# Altium schematic sheet Front end power supplies.SchDoc (continued)

## Library data of the parts
C194 C451 C452 = INVIHA-49: CAP ALUM 33UF 20% 200V SMD 10mm dia; ref_part_type: /capacitor/polarized/al/; source_manufacturer_1: Nichicon; source_manufacturer_1_pn: ULR2D330MNL1GS; cae_altium_pcb_status: Prototype; Database Table Name: altium_default; db_rev: 7664; elec_temp_range: -40 to 105 ° C; phy_height: 14.5; phy_material: Al; phy_packaging_type: Radial, CAN; ref_datasheet: INVIHA-10; ref_keyword: Aluminum electrolytic capacitor; cae_altium_sch_status: Prototype; Component Kind: Standard; ComponentLink1Description: Datasheet; ComponentLink1URL: https://cop201.prevas.dk/viewvc/datasheet/INVIHA-10.pdf?root=hw_components&view=co; db_created: 2015-08-05 05:06:02.0; db_last_updated: 05-08-2015 05:06:03; db_local_id: INVIHA-49; db_status: Prototype; elec_tolerance: 20%; elec_value: 33µF; elec_voltage_rating: 200V; phy_mounting: SMD; Library Name: altium_mysql.dblib
C208 C215 C242 C445 C450 C479 C484 C551 = INNISI-82: CAP CER 10nF 50V 10% X7R 0402; ref_part_type: /capacitor/non_polarized/ceramic/; source_manufacturer_1: Yageo; source_manufacturer_1_pn: CC0402KRX7R9BB103; cae_altium_pcb_status: Prototype; Database Table Name: altium_default; db_rev: 7579; elec_temp_range: -55 to +125C; phy_height: 0.55mm; phy_mounting: SMD; phy_packaging_type: 0402; ref_datasheet: INNISI-72; ref_keyword: Ceramic capacitor; cae_altium_sch_status: Prototype; Component Kind: Standard; ComponentLink1Description: Datasheet; ComponentLink1URL: https://cop201.prevas.dk/viewvc/datasheet/INNISI-72.pdf?root=hw_components&view=co; db_created: 2015-08-03 05:52:48.0; db_last_updated: 03-08-2015 05:52:50; db_local_id: INNISI-82; db_status: Prototype; elec_tolerance: 10%; elec_value: 10.0nF; elec_voltage_rating: 50V; phy_material: X7R; Library Name: altium_mysql.dblib
C240 C243 C546 = INVIHA-50: CAP ALUM 680UF 20% 10V SMD 8mm dia; ref_part_type: /capacitor/polarized/al/; source_manufacturer_1: Nichicon; source_manufacturer_1_pn: UCL1A681MNL1GS; cae_altium_pcb_status: Prototype; Database Table Name: altium_default; db_rev: 7665; elec_temp_range: -55°C ~ 105°C; phy_height: 10mm; phy_material: Al; phy_packaging_type: Radial, CAN; ref_datasheet: INVIHA-11; ref_keyword: Aluminum electrolytic capacitor; cae_altium_sch_status: Prototype; Component Kind: Standard; ComponentLink1Description: Datasheet; ComponentLink1URL: https://cop201.prevas.dk/viewvc/datasheet/INVIHA-11.pdf?root=hw_components&view=co; db_created: 2015-08-05 05:11:26.0; db_last_updated: 05-08-2015 05:11:28; db_local_id: INVIHA-50; db_status: Prototype; elec_tolerance: 20%; elec_value: 680uF; elec_voltage_rating: 10V; phy_mounting: SMD; Library Name: altium_mysql.dblib
C241 C550 C552 = INNISI-73: CAP CER 0.1UF 50V 10% X5R 0402; ref_part_type: /capacitor/non_polarized/ceramic/; source_manufacturer_1: TDK Corporation; source_manufacturer_1_pn: C1005X5R1H104K050BB; cae_altium_pcb_status: Prototype; Database Table Name: altium_default; db_rev: 7569; elec_temp_range: -55 to +85 C; phy_height: 0.55mm; phy_mounting: SMD; phy_packaging_type: 0402; ref_datasheet: INNISI-71; cae_altium_sch_status: Prototype; Component Kind: Standard; ComponentLink1Description: Datasheet; ComponentLink1URL: https://cop201.prevas.dk/viewvc/datasheet/INNISI-71.pdf?root=hw_components&view=co; db_created: 2015-08-03 03:34:59.0; db_last_updated: 03-08-2015 03:35:01; db_local_id: INNISI-73; db_status: Prototype; elec_tolerance: ±10%; elec_value: 0.1uF; elec_voltage_rating: 50V; phy_material: X5R; ref_keyword: CAP CER 0.1UF 50V 10% X5R 0402; Library Name: altium_mysql.dblib
C264 C548 = INNISI-85: CAP CER 1.8nF 50V 10% X7R 0402; ref_part_type: /capacitor/non_polarized/ceramic/; source_manufacturer_1: Murata; source_manufacturer_1_pn: GRM155R71H182KA01D; cae_altium_pcb_status: Prototype; Database Table Name: altium_default; db_rev: 7588; elec_temp_range: -55 to +125C; phy_height: 0.55mm; phy_mounting: SMD; phy_packaging_type: 0402; ref_datasheet: INNISI-77; ref_keyword: Ceramic capacitor X7R 0402; cae_altium_sch_status: Prototype; Component Kind: Standard; ComponentLink1Description: Datasheet; ComponentLink1URL: https://cop201.prevas.dk/viewvc/datasheet/INNISI-77.pdf?root=hw_components&view=co; db_created: 2015-08-03 06:34:28.0; db_last_updated: 03-08-2015 06:34:30; db_local_id: INNISI-85; db_status: Prototype; elec_tolerance: 10%; elec_value: 1.8nF; elec_voltage_rating: 50V; phy_material: X7R; Library Name: altium_mysql.dblib
C265 = INNISI-80: CAP CER 33PF 50V 5% NPO 0402; ref_part_type: /capacitor/non_polarized/ceramic/; source_manufacturer_1: Yageo; source_manufacturer_1_pn: CC0402JRNPO9BN330; cae_altium_pcb_status: Prototype; Database Table Name: altium_default; db_rev: 7577; elec_temp_range: -55 to +125C; phy_height: 0.55mm; phy_material: C0G,NPO; phy_mounting: SMD; phy_packaging_type: 0402; ref_datasheet: INNISI-72; ref_keyword: Multilayer chip capacitor; cae_altium_sch_status: Prototype; Component Kind: Standard; ComponentLink1Description: Datasheet; ComponentLink1URL: https://cop201.prevas.dk/viewvc/datasheet/INNISI-72.pdf?root=hw_components&view=co; db_created: 2015-08-03 05:45:15.0; db_last_updated: 03-08-2015 05:45:17; db_local_id: INNISI-80; db_status: Prototype; elec_tolerance: 5%; elec_value: 33pF; elec_voltage_rating: 50V; Library Name: altium_mysql.dblib
C438 C441 = INNISI-86: CAP CER 22nF 50V 10% X7R 0402; ref_part_type: /capacitor/non_polarized/ceramic/; source_manufacturer_1: Murata; source_manufacturer_1_pn: GRM155R71H223KA12D; cae_altium_pcb_status: Prototype; Database Table Name: altium_default; db_rev: 7591; elec_temp_range: -55 to +125C; phy_height: 0.55mm; phy_mounting: SMD; phy_packaging_type: 0402; ref_datasheet: INNISI-78; ref_keyword: Ceramic capacitor X7R 0402; cae_altium_sch_status: Prototype; Component Kind: Standard; ComponentLink1Description: Datasheet; ComponentLink1URL: https://cop201.prevas.dk/viewvc/datasheet/INNISI-78.pdf?root=hw_components&view=co; db_created: 2015-08-03 06:44:03.0; db_last_updated: 03-08-2015 06:44:05; db_local_id: INNISI-86; db_status: Prototype; elec_tolerance: 10%; elec_value: 22nF; elec_voltage_rating: 50V; phy_material: X7R; Library Name: altium_mysql.dblib
C439 C440 = INRAAN-25: Capacitor; ref_part_type: /capacitor/non_polarized/ceramic/; source_manufacturer_1: TDK; source_manufacturer_1_pn: C3225X7S2A475M200; cae_altium_pcb_status: Prototype; Database Table Name: altium_default; db_rev: 6695; elec_temp_range: -55 to 125; elec_voltage_rating: 100V; phy_height: 2.2; phy_mounting: SMD; phy_packaging_type: 1210; ref_datasheet: INRAAN-27; cae_altium_sch_status: Prototype; Component Kind: Standard; ComponentLink1Description: Datasheet; ComponentLink1URL: https://cop201.prevas.dk/viewvc/datasheet/INRAAN-27.pdf?root=hw_components&view=co; db_created: 2013-11-18 11:20:32.0; db_last_updated: 01-09-2014 04:22:26; db_local_id: INRAAN-25; db_status: Prototype; elec_value: 4.7uF; ref_keyword: Capacitor; Library Name: altium_mysql.dblib
C442 C525 = INNISI-87: CAP CER470nF 50V 10% X5R 0402; ref_part_type: /capacitor/non_polarized/ceramic/; source_manufacturer_1: Murata; source_manufacturer_1_pn: UMK105ABJ474KV-F; cae_altium_pcb_status: Prototype; Database Table Name: altium_default; db_rev: 7594; elec_temp_range: -55 to +125C; phy_height: 0.55mm; phy_mounting: SMD; phy_packaging_type: 0402; ref_datasheet: INNISI-79; ref_keyword: Ceramic capacitor X7R 0402; cae_altium_sch_status: Prototype; Component Kind: Standard; ComponentLink1Description: Datasheet; ComponentLink1URL: https://cop201.prevas.dk/viewvc/datasheet/INNISI-79.pdf?root=hw_components&view=co; db_created: 2015-08-03 06:49:53.0; db_last_updated: 03-08-2015 06:49:55; db_local_id: INNISI-87; db_status: Prototype; elec_tolerance: 10%; elec_value: 470nF; elec_voltage_rating: 50V; phy_material: X5R; Library Name: altium_mysql.dblib
C443 C449 C578 = INRAAN-25: Capacitor; ref_part_type: /capacitor/non_polarized/ceramic/; source_manufacturer_1: TDK; source_manufacturer_1_pn: C3225X7S2A475M200; cae_altium_pcb_status: Prototype; Database Table Name: altium_default; db_rev: 6695; elec_temp_range: -55 to 125; phy_height: 2.2; phy_mounting: SMD; phy_packaging_type: 1210; ref_datasheet: INRAAN-27; cae_altium_sch_status: Prototype; Component Kind: Standard; ComponentLink1Description: Datasheet; ComponentLink1URL: https://cop201.prevas.dk/viewvc/datasheet/INRAAN-27.pdf?root=hw_components&view=co; db_created: 2013-11-18 11:20:32.0; db_last_updated: 01-09-2014 04:22:26; db_local_id: INRAAN-25; db_status: Prototype; elec_value: 4.7uF; ref_keyword: Capacitor; Library Name: altium_mysql.dblib
C444 = INNISI-88: CAP CER 180PF 50V 5% NPO 0402; ref_part_type: /capacitor/non_polarized/ceramic/; source_manufacturer_1: Yageo; source_manufacturer_1_pn: CC0402JRNPO9BN181; cae_altium_pcb_status: Prototype; Database Table Name: altium_default; db_rev: 7596; elec_temp_range: -55 to +125C; phy_height: 0.55mm; phy_material: cog,npo; phy_mounting: SMD; phy_packaging_type: 0402; ref_datasheet: INNISI-42; ref_keyword: Ceramic capacitor X7R 0402; cae_altium_sch_status: Prototype; Component Kind: Standard; ComponentLink1Description: Datasheet; ComponentLink1URL: https://cop201.prevas.dk/viewvc/datasheet/INNISI-42.pdf?root=hw_components&view=co; db_created: 2015-08-03 06:54:21.0; db_last_updated: 03-08-2015 06:54:23; db_local_id: INNISI-88; db_status: Prototype; elec_tolerance: 5%; elec_value: 180pF; elec_voltage_rating: 50V; Library Name: altium_mysql.dblib
C446 = innisi-89: CAP CER 1.2nF 50V 10% X7R 0402; ref_part_type: /capacitor/non_polarized/ceramic/; source_manufacturer_1: Yageo; source_manufacturer_1_pn: CC0402KRX7R9BB122; cae_altium_pcb_status: Prototype; Database Table Name: altium_default; db_rev: 7603; elec_temp_range: -55 to +125C; phy_height: 0.55mm; phy_mounting: SMD; phy_packaging_type: 0402; ref_datasheet: INNISI-80; ref_keyword: Ceramic capacitor X7R 0402; cae_altium_sch_status: Prototype; Component Kind: Standard; ComponentLink1Description: Datasheet; ComponentLink1URL: https://cop201.prevas.dk/viewvc/datasheet/INNISI-80.pdf?root=hw_components&view=co; db_created: 2015-08-03 07:16:44.0; db_last_updated: 03-08-2015 07:16:45; db_local_id: INNISI-89; db_status: Prototype; elec_tolerance: 10%; elec_value: 1.2nF; elec_voltage_rating: 50V; phy_material: X7R; Library Name: altium_mysql.dblib
C447 = INNISI-76: CAP CER 0.22UF 16V 10% X7R 0402; ref_part_type: /capacitor/non_polarized/ceramic/; source_manufacturer_1: Murata; source_manufacturer_1_pn: GRM155R71C224KA12D; cae_altium_pcb_status: Prototype; Database Table Name: altium_default; db_rev: 7572; elec_temp_range: -55 to +125C; phy_height: 0.55mm; phy_mounting: SMD; phy_packaging_type: 0402; ref_datasheet: INNISI-73; ref_keyword: Ceramic capacitor X7R 0402; cae_altium_sch_status: Prototype; Component Kind: Standard; ComponentLink1Description: Datasheet; ComponentLink1URL: https://cop201.prevas.dk/viewvc/datasheet/INNISI-73.pdf?root=hw_components&view=co; db_created: 2015-08-03 04:28:56.0; db_last_updated: 03-08-2015 04:28:58; db_local_id: INNISI-76; db_status: Prototype; elec_tolerance: 10%; elec_value: 0.22uF; elec_voltage_rating: 16V; phy_material: X7R; Library Name: altium_mysql.dblib
C448 = INNISI-98: CAP CER 0.68UF 16V 10% X7R 0603; ref_part_type: /capacitor/non_polarized/ceramic/; source_manufacturer_1: Murata; source_manufacturer_1_pn: C1608X7R1C684K080AC; cae_altium_pcb_status: Prototype; Database Table Name: altium_default; db_rev: 7644; elec_temp_range: -55 to +125C; phy_height: 0.95mm; phy_mounting: SMD; phy_packaging_type: 0603; ref_datasheet: INNISI-71; ref_keyword: Ceramic capacitor X5R 0603; cae_altium_sch_status: Prototype; Component Kind: Standard; ComponentLink1Description: Datasheet; ComponentLink1URL: https://cop201.prevas.dk/viewvc/datasheet/INNISI-71.pdf?root=hw_components&view=co; db_created: 2015-08-03 10:13:09.0; db_last_updated: 03-08-2015 10:13:11; db_local_id: INNISI-98; db_status: Prototype; elec_tolerance: 10%; elec_value: 0.68uF; elec_voltage_rating: 16V; phy_material: X7R; Library Name: altium_mysql.dblib
C480 C481 = INNISI-102: Ceramic capacitor X5R 0603; ref_part_type: /capacitor/non_polarized/ceramic/; source_manufacturer_1: TAIYO YUDEN; source_manufacturer_1_pn: EMK107ABJ475KA-T; cae_altium_pcb_status: Prototype; Database Table Name: altium_default; db_rev: 7663; elec_temp_range: -55 to +85; elec_tolerance: 10%; elec_voltage_rating: 16V; phy_height: 0.90mm; phy_mounting: SMD; phy_packaging_type: 0603; ref_keyword: Multilayer chip capacitor; cae_altium_sch_status: Prototype; Component Kind: Standard; ComponentLink1Description: Datasheet; db_created: 2015-08-04 09:58:51.0; db_last_updated: 04-08-2015 09:58:53; db_local_id: INNISI-102; db_status: Prototype; elec_value: 4.7uF; phy_material: X5R; Library Name: altium_mysql.dblib
C482 C483 = INVIHA-51: CAP TANT 47UF 10V 10% 2312; ref_part_type: /capacitor/polarized/ta/; source_manufacturer_1: AVX Corporation; source_manufacturer_1_pn: TAJC476K010RNJ; cae_altium_pcb_status: Prototype; Database Table Name: altium_default; db_rev: 7666; elec_temp_range: -55 to 125°C; phy_height: 2.8mm; phy_material: Tantalum; phy_mounting: SMD; phy_packaging_type: 2312; ref_datasheet: INVIHA-12; ref_keyword: CAPACITOR TANT; cae_altium_sch_status: Prototype; Component Kind: Standard; ComponentLink1Description: Datasheet; ComponentLink1URL: https://cop201.prevas.dk/viewvc/datasheet/INVIHA-12.pdf?root=hw_components&view=co; db_created: 2015-08-05 05:24:04.0; db_last_updated: 05-08-2015 05:24:06; db_local_id: INVIHA-51; db_status: Prototype; elec_tolerance: 10%; elec_value: 47uF; elec_voltage_rating: 10V; Library Name: altium_mysql.dblib
C544 = innisi-90: CAP CER 100PF 16V 10% NP0 0402; ref_part_type: /capacitor/non_polarized/ceramic/; source_manufacturer_1: KEMET; source_manufacturer_1_pn: C0402C101K4GACTU; cae_altium_pcb_status: Prototype; Database Table Name: altium_default; db_rev: 7606; elec_temp_range: -55 to +125; phy_height: 0.55mm; phy_material: C0G,NP0; phy_mounting: SMD; phy_packaging_type: 0402; ref_datasheet: INNISI-81; ref_keyword: Ceramic capacitor; cae_altium_sch_status: Prototype; Component Kind: Standard; ComponentLink1Description: Datasheet; ComponentLink1URL: https://cop201.prevas.dk/viewvc/datasheet/INNISI-81.pdf?root=hw_components&view=co; db_created: 2015-08-03 07:29:35.0; db_last_updated: 03-08-2015 07:29:37; db_local_id: INNISI-90; db_status: Prototype; elec_tolerance: 10%; elec_value: 0.1nF; elec_voltage_rating: 16V; Library Name: altium_mysql.dblib
C545 = INNISI-91: CAP CER 27nF 16V 10% X7R 0402; ref_part_type: /capacitor/non_polarized/ceramic/; source_manufacturer_1: Murata; source_manufacturer_1_pn: GRM155R71C273KA01D; cae_altium_pcb_status: Prototype; Database Table Name: altium_default; db_rev: 7607; elec_temp_range: -55 to +125C; phy_height: 0.55mm; phy_mounting: SMD; phy_packaging_type: 0402; ref_datasheet: INNISI-82; ref_keyword: Ceramic capacitor X7R 0402; cae_altium_sch_status: Prototype; Component Kind: Standard; ComponentLink1Description: Datasheet; ComponentLink1URL: https://cop201.prevas.dk/viewvc/datasheet/INNISI-82.pdf?root=hw_components&view=co; db_created: 2015-08-03 07:37:08.0; db_last_updated: 03-08-2015 07:37:10; db_local_id: INNISI-91; db_status: Prototype; elec_tolerance: 10%; elec_value: 27nF; elec_voltage_rating: 16V; phy_material: X7R; Library Name: altium_mysql.dblib
C547 = INNISI-92: CAP CER 820pF 50V 5% X7R 0402; ref_part_type: /capacitor/non_polarized/ceramic/; source_manufacturer_1: Yageo; source_manufacturer_1_pn: CC0402KRX7R9BB821; cae_altium_pcb_status: Prototype; Database Table Name: altium_default; db_rev: 7609; elec_temp_range: -55 to +125C; elec_tolerance: 10%; phy_height: 0.55mm; phy_mounting: SMD; phy_packaging_type: 0402; ref_datasheet: INNISI-80; ref_keyword: Multilayer chip capacitor; cae_altium_sch_status: Prototype; Component Kind: Standard; ComponentLink1Description: Datasheet; ComponentLink1URL: https://cop201.prevas.dk/viewvc/datasheet/INNISI-80.pdf?root=hw_components&view=co; db_created: 2015-08-03 07:41:05.0; db_last_updated: 03-08-2015 07:41:06; db_local_id: INNISI-92; db_status: Prototype; elec_value: 820pF; elec_voltage_rating: 50V; phy_material: X7R; Library Name: altium_mysql.dblib
C549 = INNISI-93: CAP CER 270PF 50V 10% X7R 0402; ref_part_type: /capacitor/non_polarized/ceramic/; source_manufacturer_1: Murata; source_manufacturer_1_pn: GRM155R71H271KA01D; cae_altium_pcb_status: Prototype; Database Table Name: altium_default; db_rev: 7618; elec_temp_range: -55 to +125C; phy_height: 0.55mm; phy_mounting: SMD; phy_packaging_type: 0402; ref_datasheet: INNISI-83; ref_keyword: Ceramic capacitor X7R 0402; cae_altium_sch_status: Prototype; Component Kind: Standard; ComponentLink1Description: Datasheet; ComponentLink1URL: https://cop201.prevas.dk/viewvc/datasheet/INNISI-83.pdf?root=hw_components&view=co; db_created: 2015-08-03 08:30:40.0; db_last_updated: 03-08-2015 08:30:42; db_local_id: INNISI-93; db_status: Prototype; elec_tolerance: 10%; elec_value: 270pF; elec_voltage_rating: 50V; phy_material: X7R; Library Name: altium_mysql.dblib
C557 = INNISI-94: CAP CER 24PF 50V 5% NPO 0402; ref_part_type: /capacitor/non_polarized/ceramic/; source_manufacturer_1: Yageo; source_manufacturer_1_pn: CC0402JRNPO9BN240; cae_altium_pcb_status: Prototype; Database Table Name: altium_default; db_rev: 7627; elec_temp_range: -55 to +125C; phy_height: 0.55mm; phy_material: C0G,NP0; phy_mounting: SMD; phy_packaging_type: 0402; ref_datasheet: INNISI-80; ref_keyword: Multilayer chip capacitor; cae_altium_sch_status: Prototype; Component Kind: Standard; ComponentLink1Description: Datasheet; ComponentLink1URL: https://cop201.prevas.dk/viewvc/datasheet/INNISI-80.pdf?root=hw_components&view=co; db_created: 2015-08-03 09:08:01.0; db_last_updated: 03-08-2015 09:08:02; db_local_id: INNISI-94; db_status: Prototype; elec_tolerance: 5%; elec_value: 24pF; elec_voltage_rating: 50V; Library Name: altium_mysql.dblib
C558 C559 C579 = INNISI-45: Multilayer Ceramic Capacitors MLCC; ref_part_type: /capacitor/non_polarized/ceramic/; source_manufacturer_1: TDK; source_manufacturer_1_pn: C3225X7S2A335K200AB; cae_altium_pcb_status: Prototype; Database Table Name: altium_default; db_rev: 7401; elec_temp_range: -55 C to 125 C; elec_tolerance: 10%; phy_height: 2.5; phy_material: X7S; phy_mounting: SMD; phy_packaging_type: 1210; ref_datasheet: INNISI-43; cae_altium_sch_status: Prototype; Component Kind: Standard; ComponentLink1Description: Datasheet; ComponentLink1URL: https://cop201.prevas.dk/viewvc/datasheet/INNISI-43.pdf?root=hw_components&view=co; db_created: 2015-06-08 06:39:18.0; db_last_updated: 08-06-2015 06:41:31; db_local_id: INNISI-45; db_status: Prototype; elec_value: 3.3uF; ref_keyword: Capacitor; Library Name: altium_mysql.dblib
C580 C582 = INNISI-95: CAP CER 270PF 50V 10% X7R 0402; ref_part_type: /capacitor/non_polarized/ceramic/; source_manufacturer_1: TDK; source_manufacturer_1_pn: CGA2B1X7R1E473K050BC; cae_altium_pcb_status: Prototype; Database Table Name: altium_default; db_rev: 7628; elec_temp_range: -55 to +125C; elec_voltage_rating: 25V; phy_height: 0.55mm; phy_mounting: SMD; phy_packaging_type: 0402; ref_datasheet: INNISI-84; ref_keyword: Ceramic capacitor X7R 0402; cae_altium_sch_status: Prototype; Component Kind: Standard; ComponentLink1Description: Datasheet; ComponentLink1URL: https://cop201.prevas.dk/viewvc/datasheet/INNISI-84.pdf?root=hw_components&view=co; db_created: 2015-08-03 09:11:55.0; db_last_updated: 03-08-2015 09:11:57; db_local_id: INNISI-95; db_status: Prototype; elec_tolerance: 10%; elec_value: 47nF; phy_material: X7R; Library Name: altium_mysql.dblib
C581 = INNISI-83: CAP CER 22PF 50V 5% NP0 0402; ref_part_type: /capacitor/non_polarized/ceramic/; source_manufacturer_1: Murata; source_manufacturer_1_pn: GCM1555C1H220JA16D; cae_altium_pcb_status: Prototype; Database Table Name: altium_default; db_rev: 7582; elec_temp_range: -55 to +125C; phy_height: 0.55mm; phy_material: C0G; phy_mounting: SMD; phy_packaging_type: 0402; ref_datasheet: INNISI-76; ref_keyword: Ceramic capacitor; cae_altium_sch_status: Prototype; Component Kind: Standard; ComponentLink1Description: Datasheet; ComponentLink1URL: https://cop201.prevas.dk/viewvc/datasheet/INNISI-76.pdf?root=hw_components&view=co; db_created: 2015-08-03 06:17:55.0; db_last_updated: 03-08-2015 06:17:58; db_local_id: INNISI-83; db_status: Prototype; elec_tolerance: 5%; elec_value: 22pF; elec_voltage_rating: 50V; Library Name: altium_mysql.dblib
D25 D36 D45 = INNISI-46: Rectifiers 200 Volt 30 Amp Common Cathode; ref_part_type: /diode/schottky/; source_manufacturer_1: Vishay Semiconductors; cae_altium_pcb_status: Prototype; Database Table Name: altium_default; db_rev: 7402; elec_current_rating: 30 A; elec_temp_range: -65 C -  +175 C; elec_value: VS-30CTH02SPBF; elec_voltage_rating: 200 V Reverse Voltage; phy_mounting: SMD; phy_packaging_type: D2PAK; ref_datasheet: INNISI-44; ref_keyword: Ultra Fast Recovery Rectifiers; cae_altium_sch_status: Prototype; Component Kind: Standard; ComponentLink1Description: Datasheet; ComponentLink1URL: https://cop201.prevas.dk/viewvc/datasheet/INNISI-44.pdf?root=hw_components&view=co; db_created: 2015-06-08 09:09:20.0; db_last_updated: 08-06-2015 09:09:21; db_local_id: INNISI-46; db_status: Prototype; source_manufacturer_1_pn: VS-30CTH02SPBF; Library Name: altium_mysql.dblib
D27 D40 D42 = NIMA-249: Diode, High Speed SOD-123; ref_part_type: /diode/normal/; source_manufacturer_1: Diodes Inc; source_manufacturer_1_pn: 1N4148W-7-F; cae_altium_pcb_status: Prototype; Database Table Name: altium_default; db_rev: 6241; elec_current_rating: 150mA; elec_power_rating: 400mW; elec_temp_range: -65 to +150; elec_voltage_rating: 100V; phy_height: 1.45mm; phy_mounting: SMD; phy_packaging_type: SOD-123F; ref_datasheet: NIMA-181; ref_keyword: Diode SMD, BAV16W/1N4148W; cae_altium_sch_status: Prototype; Component Kind: Standard; ComponentLink1Description: Datasheet; ComponentLink1URL: https://cop201.prevas.dk/viewvc/datasheet/NIMA-181.pdf?root=hw_components&view=co; db_created: 2012-06-27 20:48:14.0; db_last_updated: 12/20/2013 10:44:53 AM; db_local_id: NIMA-249; db_status: Prototype; elec_value: 1N4148W; Library Name: altium_mysql.dblib
D35 = INNISI-47: DIODE SCHOTTKY 100V 8A; ref_part_type: /diode/schottky/; source_manufacturer_1: Micro Commercial Co; cae_altium_pcb_status: Prototype; Database Table Name: altium_default; db_rev: 7403; elec_temp_range: - 55 to 150ºC; phy_material: Epoxy; phy_mounting: SMD; phy_packaging_type: DO-214AB; ref_datasheet: INNISI-45; ref_keyword: Schottky Diode; cae_altium_sch_status: Prototype; Component Kind: Standard; ComponentLink1Description: Datasheet; ComponentLink1URL: https://cop201.prevas.dk/viewvc/datasheet/INNISI-45.pdf?root=hw_components&view=co; db_created: 2015-06-08 09:46:34.0; db_last_updated: 08-06-2015 09:46:36; db_local_id: INNISI-47; db_status: Prototype; elec_current_rating: 8A; elec_value: SK810L-TP; elec_voltage_rating: 100V; source_manufacturer_1_pn: SK810L-TP; Library Name: altium_mysql.dblib
D38 D48-D52 = NIMA-251: Diode Power Rectifier Case DO-214AB; ref_part_type: /diode/schottky/; source_manufacturer_1: STMicroelectronics; cae_altium_pcb_status: Prototype; Database Table Name: altium_default; db_rev: 4795; elec_current_rating: 5A; elec_temp_range: -65 to 150; elec_voltage_rating: 60V; phy_height: 2.65mm; phy_material: Epoxy; phy_mounting: SMD; phy_packaging_type: SMC; ref_datasheet: NIMA-182; ref_keyword: Schottky Diode SMD; cae_altium_sch_status: Prototype; Component Kind: Standard; ComponentLink1Description: Datasheet; ComponentLink1URL: https://cop201.prevas.dk/viewvc/datasheet/NIMA-182.pdf?root=hw_components&view=co; db_created: 2012-06-27 23:43:13.0; db_local_id: NIMA-251; db_status: Prototype; elec_value: STPS5L60S; source_manufacturer_1_pn: STPS5L60S; Library Name: altium_mysql.dblib
  D38: db_last_updated: 27-06-2012 23:43:13
  D48-D52: db_last_updated: 6/27/2012 11:43:13 PM
D39 D41 D43 D60 = JEPE-25: Zener Diode Small Signal, 5.1V, SOT-23; ref_part_type: /diode/zener/; source_manufacturer_1: Vishay; source_manufacturer_1_pn: BZX84C5V1-V; cae_altium_pcb_status: Prototype; Database Table Name: altium_default; db_rev: 2170; elec_power_rating: 300mW; elec_temp_range: -65 to +150; elec_tolerance: 5%; elec_value: BZX84C5V1; phy_height: 1.15mm; phy_mounting: SMD; ref_datasheet: JEPE-16; ref_keyword: Zener Diode SMD; cae_altium_sch_status: Prototype; Component Kind: Standard; ComponentLink1Description: Datasheet; ComponentLink1URL: https://cop201.prevas.dk/viewvc/datasheet/JEPE-16.pdf?root=hw_components&view=co; db_created: 2008-05-28 14:32:26; db_last_updated: 04-03-2010 11:25:40; db_local_id: JEPE-25; db_status: Prototype; elec_voltage_rating: 5.1V; phy_packaging_type: SOT-23; Library Name: altium_mysql.dblib
D78 = GEPW-161: SMD LED, 570nm, 70mcd; ref_part_type: /optical/emmision/diode/; source_manufacturer_1: Kingbright; cae_altium_pcb_status: Prototype; Database Table Name: altium_default; db_rev: 3358; elec_current_rating: IF=20mA; elec_temp_range: -40 to 85; elec_voltage_rating: 2.1V; phy_height: 0.25; phy_packaging_type: 0603; ref_datasheet: GEPW-139; ref_keyword: Green; cae_altium_sch_status: Prototype; Component Kind: Standard; ComponentLink1Description: Datasheet; ComponentLink1URL: https://cop201.prevas.dk/viewvc/datasheet/GEPW-139.pdf?root=hw_components&view=co; db_created: 2011-04-26 11:17:00.0; db_last_updated: 26-04-2011 11:17:00; db_local_id: GEPW-161; db_status: Prototype; elec_frequency_rating: 570nm; elec_value: APG1608CGKC/T; phy_mounting: SMD; source_manufacturer_1_pn: APG1608CGKC/T; Library Name: altium_mysql.dblib
L35 = INRAAN-100: Shielded Power Inductors –XAL1010; ref_part_type: /inductor/power/; source_manufacturer_1: Coilcraft; source_manufacturer_1_pn: XAL1010-153MEB; cae_altium_pcb_status: Prototype; Database Table Name: altium_default; db_rev: 6549; elec_current_rating: 13.8A; elec_temp_range: -40 C to 125 C; phy_height: 10mm; phy_material: Composite; phy_mounting: SMD; ref_datasheet: INRAAN-102; cae_altium_sch_status: Prototype; Component Kind: Standard; ComponentLink1Description: Datasheet; ComponentLink1URL: https://cop201.prevas.dk/viewvc/datasheet/INRAAN-102.pdf?root=hw_components&view=co; db_created: 2014-02-20 06:38:34.0; db_last_updated: 10-04-2014 12:19:15; db_local_id: INRAAN-100; db_status: Prototype; elec_value: 15 uH; ref_keyword: Shielded Power Inductors –XAL1010; Library Name: altium_mysql.dblib
L40 = INNISI-49: Fixed Inductors XAL6060 High Current; ref_part_type: /inductor/power/; source_manufacturer_1: Coilcraft; source_manufacturer_1_pn: XAL6060-562MEB; cae_altium_pcb_status: Prototype; Database Table Name: altium_default; db_rev: 7406; elec_current_rating: 10 A; elec_temp_range: - 40 C to + 125 C; elec_tolerance: 20 %; phy_height: 6.1mm; phy_material: composite; phy_mounting: SMD; ref_datasheet: INNISI-47; ref_keyword: power Inductor; cae_altium_sch_status: Prototype; Component Kind: Standard; ComponentLink1Description: Datasheet; ComponentLink1URL: https://cop201.prevas.dk/viewvc/datasheet/INNISI-47.pdf?root=hw_components&view=co; db_created: 2015-06-08 10:33:21.0; db_last_updated: 08-06-2015 10:33:23; db_local_id: INNISI-49; db_status: Prototype; elec_value: 5.6 uH; Library Name: altium_mysql.dblib
L41 = INNISI-50: Fixed Inductors XAL7070 High Current; ref_part_type: /inductor/power/; source_manufacturer_1: Coilcraft; source_manufacturer_1_pn: XAL7070-332MEB; cae_altium_pcb_status: Prototype; Database Table Name: altium_default; db_rev: 7407; elec_current_rating: 15.1 A; elec_temp_range: - 40 C to + 125 C; elec_tolerance: 20 %; phy_height: 7mm; phy_material: composite; phy_mounting: SMD; ref_datasheet: INNISI-48; ref_keyword: power Inductor; cae_altium_sch_status: Prototype; Component Kind: Standard; ComponentLink1Description: Datasheet; ComponentLink1URL: https://cop201.prevas.dk/viewvc/datasheet/INNISI-48.pdf?root=hw_components&view=co; db_created: 2015-06-08 10:43:36.0; db_last_updated: 08-06-2015 10:43:38; db_local_id: INNISI-50; db_status: Prototype; elec_value: 3.3 uH; Library Name: altium_mysql.dblib
L48 = KIJA-84: Choke Coiles; ref_part_type: /inductor/power/; source_manufacturer_1: TDK; source_manufacturer_1_pn: RLF12545T-100M5R1-PF; cae_altium_sch_status: Prototype; Database Table Name: altium_default; db_rev: 3338; elec_current_rating: 5.1A; elec_frequency_rating: 100kHz; elec_tolerance: 20%; phy_height: 4.5mm; phy_material: Feritte; phy_mounting: SMD; ref_datasheet: KIJA-81; ref_keyword: Low DC res; Component Kind: Standard; ComponentLink1Description: Datasheet; ComponentLink1URL: https://cop201.prevas.dk/viewvc/datasheet/KIJA-81.pdf?root=hw_components&view=co; db_created: 2011-04-19 13:23:55.0; db_last_updated: 19-04-2011 13:25:36; db_local_id: KIJA-84; db_status: Prototype; elec_value: 10uH; Library Name: altium_mysql.dblib
M1-M3 = INNISI-52: MOSFET, N-channel; ref_part_type: /transistor/mosfet/n_channel/; source_manufacturer_1: Texas Instruments; cae_altium_pcb_status: Prototype; Database Table Name: altium_default; db_rev: 7409; elec_current_rating: 75A; elec_power_rating: 3.2W; elec_temp_range: -55°C to +150°C; elec_voltage_rating: 100V; phy_mounting: SMD; phy_packaging_type: 8-TDFN Exposed Pad; ref_datasheet: INNISI-50; cae_altium_sch_status: Prototype; Component Kind: Standard; ComponentLink1Description: Datasheet; ComponentLink1URL: https://cop201.prevas.dk/viewvc/datasheet/INNISI-50.pdf?root=hw_components&view=co; db_created: 2015-06-09 04:16:36.0; db_last_updated: 09-06-2015 04:16:38; db_local_id: INNISI-52; db_status: Prototype; elec_value: CSD19533Q5A; ref_keyword: MOSFET, N-channel; source_manufacturer_1_pn: CSD19533Q5A; Library Name: altium_mysql.dblib
R9 R291 R474 = INVIHA-3: RES SMD 10K OHM 1% 1/10W 0603; ref_part_type: /resistor/fixed/; source_manufacturer_1: Yageo; source_manufacturer_1_pn: RC0603FR-0710KL; cae_altium_pcb_status: Prototype; Database Table Name: altium_default; db_rev: 7575; elec_power_rating: 0.1W; elec_temp_range: -55 to +155° C; phy_height: 0.55mm; phy_packaging_type: 0603; ref_datasheet: INVIHA-2; ref_keyword: Chip Resistor; cae_altium_sch_status: Prototype; Component Kind: Standard; ComponentLink1Description: Datasheet; ComponentLink1URL: https://cop201.prevas.dk/viewvc/datasheet/INVIHA-2.pdf?root=hw_components&view=co; db_created: 2015-08-03 05:39:03.0; db_last_updated: 03-08-2015 5:39:05 AM; db_local_id: INVIHA-3; db_status: Prototype; elec_tolerance: 1%; elec_value: 10K; phy_mounting: SMD; Library Name: altium_mysql.dblib
R206 = INNISI-28: Current Sense Resistors - SMD 1W 0.010ohm 1%; ref_part_type: /resistor/; source_manufacturer_1: Susumu; source_manufacturer_1_pn: PRL1632-R009-F-T1; cae_altium_pcb_status: Prototype; Database Table Name: altium_default; db_rev: 7361; phy_height: 0.5mm; phy_packaging_type: 1206 (3216 metric); cae_altium_sch_status: Prototype; Component Kind: Standard; ComponentLink1Description: Datasheet; ComponentLink1URL: https://cop201.prevas.dk/viewvc/datasheet/INNISI-28.pdf?root=hw_components&view=co; db_created: 2015-06-02 11:00:38.0; db_last_updated: 02-06-2015 11:00:40; db_local_id: INNISI-28; db_status: Prototype; elec_power_rating: 1 W; elec_tolerance: 1 %; elec_value: 0.009 Ohms; phy_mounting: SMD; ref_datasheet: INNISI-28; ref_keyword: Current Sense Resistors; Library Name: altium_mysql.dblib
R390 = INVIHA-32: RES SMD 24.9K OHM 1% 1/10W 0603; ref_part_type: /resistor/fixed/; source_manufacturer_1: Yageo; source_manufacturer_1_pn: RC0603FR-0724K9L; cae_altium_pcb_status: Prototype; Database Table Name: altium_default; db_rev: 7623; elec_power_rating: 0.1W; elec_temp_range: -55 to +155° C; phy_height: 0.55mm; phy_packaging_type: 0603; ref_datasheet: INVIHA-2; ref_keyword: Chip Resistor; cae_altium_sch_status: Prototype; Component Kind: Standard; ComponentLink1Description: Datasheet; ComponentLink1URL: https://cop201.prevas.dk/viewvc/datasheet/INVIHA-2.pdf?root=hw_components&view=co; db_created: 2015-08-03 08:48:07.0; db_last_updated: 03-08-2015 8:48:08 AM; db_local_id: INVIHA-32; db_status: Prototype; elec_tolerance: 1%; elec_value: 24.9K; phy_mounting: SMD; Library Name: altium_mysql.dblib
R392 = INVIHA-46: RES SMD 68.1K OHM 1% 1/10W 0603; ref_part_type: /resistor/fixed/; source_manufacturer_1: Yageo; source_manufacturer_1_pn: CRCW060368K1FKEA; cae_altium_pcb_status: Prototype; Database Table Name: altium_default; db_rev: 7642; elec_power_rating: 0.1W; elec_temp_range: -55 to +155° C; phy_height: 0.55mm; phy_packaging_type: 0603; ref_datasheet: INVIHA-8; ref_keyword: Chip Resistor; cae_altium_sch_status: Prototype; Component Kind: Standard; ComponentLink1Description: Datasheet; ComponentLink1URL: https://cop201.prevas.dk/viewvc/datasheet/INVIHA-8.pdf?root=hw_components&view=co; db_created: 2015-08-03 09:48:26.0; db_last_updated: 03-08-2015 9:48:28 AM; db_local_id: INVIHA-46; db_status: Prototype; elec_tolerance: 1%; elec_value: 68.1K; phy_mounting: SMD; Library Name: altium_mysql.dblib
R393 R458 = INVIHA-33: RES SMD 1.91K OHM 1% 1/10W 0603; ref_part_type: /resistor/fixed/; source_manufacturer_1: Yageo; source_manufacturer_1_pn: RC0603FR-071K91L; cae_altium_pcb_status: Prototype; Database Table Name: altium_default; db_rev: 7624; elec_power_rating: 0.1W; elec_temp_range: -55 to +155° C; phy_height: 0.55mm; phy_packaging_type: 0603; ref_datasheet: INVIHA-2; ref_keyword: Chip Resistor; cae_altium_sch_status: Prototype; Component Kind: Standard; ComponentLink1Description: Datasheet; ComponentLink1URL: https://cop201.prevas.dk/viewvc/datasheet/INVIHA-2.pdf?root=hw_components&view=co; db_created: 2015-08-03 08:52:00.0; db_last_updated: 03-08-2015 8:52:02 AM; db_local_id: INVIHA-33; db_status: Prototype; elec_tolerance: 1%; elec_value: 1.91K; phy_mounting: SMD; Library Name: altium_mysql.dblib
R418 R454 = INVIHA-12: RES SMD 49.9K OHM 1% 1/10W 0603; ref_part_type: /resistor/fixed/; source_manufacturer_1: Yageo; source_manufacturer_1_pn: RC0603FR-0749K9L; cae_altium_pcb_status: Prototype; Database Table Name: altium_default; db_rev: 7592; elec_power_rating: 0.1W; elec_temp_range: -55 to +155° C; phy_height: 0.55mm; phy_packaging_type: 0603; ref_datasheet: INVIHA-2; ref_keyword: Chip Resistor; cae_altium_sch_status: Prototype; Component Kind: Standard; ComponentLink1Description: Datasheet; ComponentLink1URL: https://cop201.prevas.dk/viewvc/datasheet/INVIHA-2.pdf?root=hw_components&view=co; db_created: 2015-08-03 06:44:06.0; db_last_updated: 03-08-2015 6:44:08 AM; db_local_id: INVIHA-12; db_status: Prototype; elec_tolerance: 1%; elec_value: 49.9K; phy_mounting: SMD; Library Name: altium_mysql.dblib
R419 R457 = INVIHA-34: RES SMD 11.5K OHM 1% 1/10W 0603; ref_part_type: /resistor/fixed/; source_manufacturer_1: Yageo; source_manufacturer_1_pn: RC0603FR-0711K5L; cae_altium_pcb_status: Prototype; Database Table Name: altium_default; db_rev: 7625; elec_power_rating: 0.1W; elec_temp_range: -55 to +155° C; phy_height: 0.55mm; phy_packaging_type: 0603; ref_datasheet: INVIHA-2; ref_keyword: Chip Resistor; cae_altium_sch_status: Prototype; Component Kind: Standard; ComponentLink1Description: Datasheet; ComponentLink1URL: https://cop201.prevas.dk/viewvc/datasheet/INVIHA-2.pdf?root=hw_components&view=co; db_created: 2015-08-03 09:00:10.0; db_last_updated: 03-08-2015 9:00:12 AM; db_local_id: INVIHA-34; db_status: Prototype; elec_tolerance: 1%; elec_value: 11.5K; phy_mounting: SMD; Library Name: altium_mysql.dblib
R420 R428 R459 = INVIHA-31: RES SMD 1M OHM 1% 1/10W 0603; ref_part_type: /resistor/fixed/; source_manufacturer_1: Yageo; source_manufacturer_1_pn: RC0603FR-071ML; cae_altium_pcb_status: Prototype; Database Table Name: altium_default; db_rev: 7622; elec_power_rating: 0.1W; elec_temp_range: -55 to +155° C; phy_height: 0.55mm; phy_packaging_type: 0603; ref_datasheet: INVIHA-2; ref_keyword: Chip Resistor; cae_altium_sch_status: Prototype; Component Kind: Standard; ComponentLink1Description: Datasheet; ComponentLink1URL: https://cop201.prevas.dk/viewvc/datasheet/INVIHA-2.pdf?root=hw_components&view=co; db_created: 2015-08-03 08:46:27.0; db_last_updated: 03-08-2015 8:46:29 AM; db_local_id: INVIHA-31; db_status: Prototype; elec_tolerance: 1%; elec_value: 1M; phy_mounting: SMD; Library Name: altium_mysql.dblib
R421 = INVIHA-47: RES SMD 3.92K OHM 1% 1/10W 0603; ref_part_type: /resistor/fixed/; source_manufacturer_1: Stackpole Electronics Inc; source_manufacturer_1_pn: RMCF0603FT3K92; cae_altium_pcb_status: Prototype; Database Table Name: altium_default; db_rev: 7643; elec_power_rating: 0.1W; elec_temp_range: -55 to +155° C; phy_height: 0.55mm; phy_packaging_type: 0603; ref_datasheet: INVIHA-9; ref_keyword: Chip Resistor; cae_altium_sch_status: Prototype; Component Kind: Standard; ComponentLink1Description: Datasheet; ComponentLink1URL: https://cop201.prevas.dk/viewvc/datasheet/INVIHA-9.pdf?root=hw_components&view=co; db_created: 2015-08-03 09:53:16.0; db_last_updated: 03-08-2015 9:53:18 AM; db_local_id: INVIHA-47; db_status: Prototype; elec_tolerance: 1%; elec_value: 3.92K; phy_mounting: SMD; Library Name: altium_mysql.dblib
R422 R455 = INVIHA-35: RES SMD 10.7K OHM 1% 1/10W 0603; ref_part_type: /resistor/fixed/; source_manufacturer_1: Yageo; source_manufacturer_1_pn: RC0603FR-0710K7L; cae_altium_pcb_status: Prototype; Database Table Name: altium_default; db_rev: 7626; elec_power_rating: 0.1W; elec_temp_range: -55 to +155° C; phy_height: 0.55mm; phy_packaging_type: 0603; ref_datasheet: INVIHA-2; ref_keyword: Chip Resistor; cae_altium_sch_status: Prototype; Component Kind: Standard; ComponentLink1Description: Datasheet; ComponentLink1URL: https://cop201.prevas.dk/viewvc/datasheet/INVIHA-2.pdf?root=hw_components&view=co; db_created: 2015-08-03 09:05:09.0; db_last_updated: 03-08-2015 9:05:11 AM; db_local_id: INVIHA-35; db_status: Prototype; elec_tolerance: 1%; elec_value: 10.7K; phy_mounting: SMD; Library Name: altium_mysql.dblib
R423 = INVIHA-45: RES SMD 34K OHM 1% 1/10W 0603; ref_part_type: /resistor/fixed/; source_manufacturer_1: Vishay Dale; source_manufacturer_1_pn: CRCW060334K0FKEA; cae_altium_pcb_status: Prototype; Database Table Name: altium_default; db_rev: 7641; elec_power_rating: 0.1W; elec_temp_range: -55 to +155° C; phy_height: 0.55mm; phy_packaging_type: 0603; ref_datasheet: INVIHA-7; ref_keyword: Chip Resistor; cae_altium_sch_status: Prototype; Component Kind: Standard; ComponentLink1Description: Datasheet; ComponentLink1URL: https://cop201.prevas.dk/viewvc/datasheet/INVIHA-7.pdf?root=hw_components&view=co; db_created: 2015-08-03 09:43:11.0; db_last_updated: 03-08-2015 9:43:13 AM; db_local_id: INVIHA-45; db_status: Prototype; elec_tolerance: 1%; elec_value: 34K; phy_mounting: SMD; Library Name: altium_mysql.dblib
R424 = INVIHA-36: RES SMD 1.58K OHM 1% 1/10W 0603; ref_part_type: /resistor/fixed/; source_manufacturer_1: Panasonic Electronic Components; source_manufacturer_1_pn: ERJ-3EKF1581V; cae_altium_pcb_status: Prototype; Database Table Name: altium_default; db_rev: 7629; elec_power_rating: 0.1W; elec_temp_range: -55 to +155° C; phy_height: 0.55mm; phy_packaging_type: 0603; ref_datasheet: INVIHA-6; ref_keyword: Chip Resistor; cae_altium_sch_status: Prototype; Component Kind: Standard; ComponentLink1Description: Datasheet; ComponentLink1URL: https://cop201.prevas.dk/viewvc/datasheet/INVIHA-6.pdf?root=hw_components&view=co; db_created: 2015-08-03 09:12:22.0; db_last_updated: 03-08-2015 9:12:24 AM; db_local_id: INVIHA-36; db_status: Prototype; elec_tolerance: 1%; elec_value: 1.58K; phy_mounting: SMD; Library Name: altium_mysql.dblib
R425 = INNISI-56: Current Sensing Resistor; ref_part_type: /resistor/fixed/; source_manufacturer_1: Stackpole Electronics Inc; source_manufacturer_1_pn: CSR1206FK12L0; cae_altium_pcb_status: Prototype; Database Table Name: altium_default; db_rev: 7413; elec_power_rating: 0.5W; elec_temp_range: -55C to +155C; phy_height: 0.65mm; phy_material: Thick Film; phy_mounting: SMD; phy_packaging_type: 1206; ref_datasheet: INNISI-54; ref_keyword: Thick Film Chip Resistor; cae_altium_sch_status: Prototype; Component Kind: Standard; ComponentLink1Description: Datasheet; ComponentLink1URL: https://cop201.prevas.dk/viewvc/datasheet/INNISI-54.pdf?root=hw_components&view=co; db_created: 2015-06-09 05:53:07.0; db_last_updated: 09-06-2015 05:53:09; db_local_id: INNISI-56; db_status: Prototype; elec_value: 0.012 R; Library Name: altium_mysql.dblib
R426 = INNISI-27: Current Sense Resistors - SMD 1W 0.010ohm 1%; ref_part_type: /resistor/; source_manufacturer_1: Susumu; source_manufacturer_1_pn: PRL1632-R010-F-T1; cae_altium_pcb_status: Prototype; Database Table Name: altium_default; db_rev: 7360; phy_height: 0.5mm; phy_packaging_type: 1206 (3216 metric); cae_altium_sch_status: Prototype; Component Kind: Standard; ComponentLink1Description: Datasheet; ComponentLink1URL: https://cop201.prevas.dk/viewvc/datasheet/INNISI-27.pdf?root=hw_components&view=co; db_created: 2015-06-02 10:57:59.0; db_last_updated: 02-06-2015 10:58:01; db_local_id: INNISI-27; db_status: Prototype; elec_power_rating: 1 W; elec_tolerance: 1 %; elec_value: 0.01 Ohms; phy_mounting: SMD; ref_datasheet: INNISI-27; ref_keyword: Current Sense Resistors; Library Name: altium_mysql.dblib
R429 = INVIHA-37: RES SMD 3.32K OHM 1% 1/10W 0603; ref_part_type: /resistor/fixed/; source_manufacturer_1: Yageo; source_manufacturer_1_pn: RC0603FR-073K32L; cae_altium_pcb_status: Prototype; Database Table Name: altium_default; db_rev: 7630; elec_power_rating: 0.1W; elec_temp_range: -55 to +155° C; phy_height: 0.55mm; phy_packaging_type: 0603; ref_datasheet: INVIHA-2; ref_keyword: Chip Resistor; cae_altium_sch_status: Prototype; Component Kind: Standard; ComponentLink1Description: Datasheet; ComponentLink1URL: https://cop201.prevas.dk/viewvc/datasheet/INVIHA-2.pdf?root=hw_components&view=co; db_created: 2015-08-03 09:15:26.0; db_last_updated: 03-08-2015 9:15:27 AM; db_local_id: INVIHA-37; db_status: Prototype; elec_tolerance: 1%; elec_value: 3.32K; phy_mounting: SMD; Library Name: altium_mysql.dblib
R456 = INVIHA-38: RES SMD 47.5K OHM 1% 1/10W 0603; ref_part_type: /resistor/fixed/; source_manufacturer_1: Yageo; source_manufacturer_1_pn: RC0603FR-0747K5L; cae_altium_pcb_status: Prototype; Database Table Name: altium_default; db_rev: 7631; elec_power_rating: 0.1W; elec_temp_range: -55 to +155° C; phy_height: 0.55mm; phy_packaging_type: 0603; ref_datasheet: INVIHA-2; ref_keyword: Chip Resistor; cae_altium_sch_status: Prototype; Component Kind: Standard; ComponentLink1Description: Datasheet; ComponentLink1URL: https://cop201.prevas.dk/viewvc/datasheet/INVIHA-2.pdf?root=hw_components&view=co; db_created: 2015-08-03 09:21:33.0; db_last_updated: 03-08-2015 9:21:35 AM; db_local_id: INVIHA-38; db_status: Prototype; elec_tolerance: 1%; elec_value: 47.5K; phy_mounting: SMD; Library Name: altium_mysql.dblib
R472 = INVIHA-20: RES SMD 100K OHM 1% 1/10W 0603; ref_part_type: /resistor/fixed/; source_manufacturer_1: Yageo; source_manufacturer_1_pn: RC0603FR-07100KL; cae_altium_pcb_status: Prototype; Database Table Name: altium_default; db_rev: 7602; elec_power_rating: 0.1W; elec_temp_range: -55 to +155° C; phy_height: 0.55mm; phy_packaging_type: 0603; ref_keyword: Chip Resistor; cae_altium_sch_status: Prototype; Component Kind: Standard; ComponentLink1Description: Datasheet; ComponentLink1URL: https://cop201.prevas.dk/viewvc/datasheet/INVIHA-2.pdf?root=hw_components&view=co; db_created: 2015-08-03 07:16:43.0; db_last_updated: 03-08-2015 7:16:45 AM; db_local_id: INVIHA-20; db_status: Prototype; elec_tolerance: 1%; elec_value: 100K; phy_mounting: SMD; ref_datasheet: INVIHA-2; Library Name: altium_mysql.dblib
R473 R496 R497 R500 R501 R504 R505 = INVIHA-55: RES SMD 10 OHM 1% 1/4W 0603; ref_part_type: /resistor/fixed/; source_manufacturer_1: Vishay Dale; source_manufacturer_1_pn: CRCW060310R0FKEAHP; cae_altium_pcb_status: Prototype; Database Table Name: altium_default; db_rev: 7688; elec_power_rating: 0.25W; elec_temp_range: -55 to +155° C; phy_height: 0.55mm; phy_packaging_type: 0603; ref_datasheet: INVIHA-13; ref_keyword: Chip Resistor; cae_altium_sch_status: Prototype; Component Kind: Standard; ComponentLink1Description: Datasheet; ComponentLink1URL: https://cop201.prevas.dk/viewvc/datasheet/INVIHA-13.pdf?root=hw_components&view=co; db_created: 2015-08-06 06:12:47.0; db_last_updated: 06-08-2015 06:12:49; db_local_id: INVIHA-55; db_status: Prototype; elec_tolerance: 1%; elec_value: 10R; phy_mounting: SMD; Library Name: altium_mysql.dblib
R475 = INVIHA-11: RES SMD 1K OHM 1% 1/10W 0603; ref_part_type: /resistor/fixed/; source_manufacturer_1: Yageo; source_manufacturer_1_pn: RC0603FR-071KL; cae_altium_pcb_status: Prototype; Database Table Name: altium_default; db_rev: 7590; elec_power_rating: 0.1W; elec_temp_range: -55 to +155° C; phy_height: 0.55mm; phy_packaging_type: 0603; ref_datasheet: INVIHA-2; ref_keyword: Chip Resistor; cae_altium_sch_status: Prototype; Component Kind: Standard; ComponentLink1Description: Datasheet; ComponentLink1URL: https://cop201.prevas.dk/viewvc/datasheet/INVIHA-2.pdf?root=hw_components&view=co; db_created: 2015-08-03 06:39:44.0; db_last_updated: 03-08-2015 6:39:46 AM; db_local_id: INVIHA-11; db_status: Prototype; elec_tolerance: 1%; elec_value: 1K; phy_mounting: SMD; Library Name: altium_mysql.dblib
R476 = INVIHA-39: RES SMD 3.24K OHM 1% 1/10W 0603; ref_part_type: /resistor/fixed/; source_manufacturer_1: Yageo; source_manufacturer_1_pn: RC0603FR-073K24L; cae_altium_pcb_status: Prototype; Database Table Name: altium_default; db_rev: 7632; elec_power_rating: 0.1W; elec_temp_range: -55 to +155° C; phy_height: 0.55mm; phy_packaging_type: 0603; ref_datasheet: INVIHA-2; ref_keyword: Chip Resistor; cae_altium_sch_status: Prototype; Component Kind: Standard; ComponentLink1Description: Datasheet; ComponentLink1URL: https://cop201.prevas.dk/viewvc/datasheet/INVIHA-2.pdf?root=hw_components&view=co; db_created: 2015-08-03 09:23:13.0; db_last_updated: 03-08-2015 9:23:15 AM; db_local_id: INVIHA-39; db_status: Prototype; elec_tolerance: 1%; elec_value: 3.24K; phy_mounting: SMD; Library Name: altium_mysql.dblib
R495 R498 R502 = SOMO-30: Resistor 1206 General Purpose; ref_part_type: /resistor/fixed/; source_manufacturer_1: Phycomp; source_manufacturer_1_pn: RC1206JR-**0R; cae_altium_pcb_status: Prototype; Database Table Name: altium_default; db_rev: 3087; elec_current_rating: 2A; elec_power_rating: 0.25W; elec_temp_range: -55 to +155; elec_voltage_rating: 200V; phy_height: 0.65mm; phy_material: Thick Film; phy_mounting: SMD; phy_packaging_type: 1206; ref_datasheet: JEPE-90; ref_keyword: Thick Film Chip Resistor; cae_altium_sch_status: Prototype; Component Kind: Standard; ComponentLink1Description: Datasheet; ComponentLink1URL: https://cop201.prevas.dk/viewvc/datasheet/JEPE-90.pdf?root=hw_components&view=co; db_created: 2011-01-06 16:09:25.0; db_last_updated: 06-01-2011 4:09:25 PM; db_local_id: SOMO-30; db_status: Prototype; elec_value: 0R; Library Name: altium_mysql.dblib
R499 R503 = INVIHA-6: RES SMD 100 OHM 1% 1/10W 0603; ref_part_type: /resistor/fixed/; source_manufacturer_1: Yageo; source_manufacturer_1_pn: RC0603FR-07100RL; cae_altium_pcb_status: Prototype; Database Table Name: altium_default; db_rev: 7583; elec_power_rating: 0.1W; elec_temp_range: -55 to +155° C; phy_height: 0.55mm; phy_packaging_type: 0603; ref_datasheet: INVIHA-2; ref_keyword: Chip Resistor; cae_altium_sch_status: Prototype; Component Kind: Standard; ComponentLink1Description: Datasheet; ComponentLink1URL: https://cop201.prevas.dk/viewvc/datasheet/INVIHA-2.pdf?root=hw_components&view=co; db_created: 2015-08-03 06:19:06.0; db_last_updated: 03-08-2015 6:19:07 AM; db_local_id: INVIHA-6; db_status: Prototype; elec_tolerance: 1%; elec_value: 100R; phy_mounting: SMD; Library Name: altium_mysql.dblib
TP70 TP71 TP86-TP93 = JEPE-114: Test Point SMD; ref_part_type: /pcb_item/; cae_altium_pcb_status: Prototype; Database Table Name: altium_default; db_rev: 3281; cae_altium_sch_status: Prototype; ComponentLink1Description: Datasheet; db_created: 2008-08-18 11:39:42; db_last_updated: 23-03-2011 14:14:06; db_local_id: JEPE-114; db_status: Prototype; elec_value: Test Point; phy_mounting: SMD; ref_keyword: Test  Point; Library Name: altium_mysql.dblib
U25-U27 = INNISI-11: Switching Controllers 5V Non-Synch Ecm Buck Contr; ref_part_type: /integrated/analog/power/supply/linear/; source_manufacturer_1: Texas Instruments; source_manufacturer_1_pn: LM5088MH-1/NOPB; cae_altium_pcb_status: Prototype; Database Table Name: altium_default; db_rev: 7330; elec_current_rating: 10A; elec_frequency_rating: 50 kHz to 1 MHz; elec_temp_range: -40C to +125C; elec_value: LM5088MH-2; elec_voltage_rating: 4.5 V to 75 V; phy_mounting: SMD; phy_packaging_type: TSSOP EP; ref_keyword: Switching Regulator; cae_altium_sch_status: Prototype; Component Kind: Standard; ComponentLink1Description: Datasheet; ComponentLink1URL: https://cop201.prevas.dk/viewvc/datasheet/INNISI-11.pdf?root=hw_components&view=co; db_created: 2015-05-27 11:31:51.0; db_last_updated: 28-05-2015 03:15:44; db_local_id: INNISI-11; db_status: Prototype; ref_datasheet: INNISI-11; Library Name: altium_mysql.dblib
U30 = INRAAN-7: 5A, 32V, 500kHz Step-Down Converter; ref_part_type: /integrated/converter/Voltage to Current/; source_manufacturer_1: RICHTEK; cae_altium_pcb_status: Prototype; Database Table Name: altium_default; db_rev: 6244; elec_temp_range: -40 to 125; elec_voltage_rating: 5.5 to 32V; phy_height: 1.75; phy_mounting: SMD; phy_packaging_type: SOP; ref_datasheet: INRAAN-8; cae_altium_sch_status: Prototype; Component Kind: Standard; ComponentLink1Description: Datasheet; ComponentLink1URL: https://cop201.prevas.dk/viewvc/datasheet/INRAAN-8.pdf?root=hw_components&view=co; db_created: 2013-10-24 12:42:58.0; db_last_updated: 27-12-2013 07:47:53; db_local_id: INRAAN-7; db_status: Prototype; elec_current_rating: 5A; elec_frequency_rating: 500kHz; elec_value: RT8289; ref_keyword: 5A, 32V, 500kHz Step-Down Converter; source_manufacturer_1_pn: RT8289; Supplier 1: Digi-Key; Library Name: altium_mysql.dblib
